# Altium schematic sheet pultboard_buttons.SchDoc(pultboard_buttons)
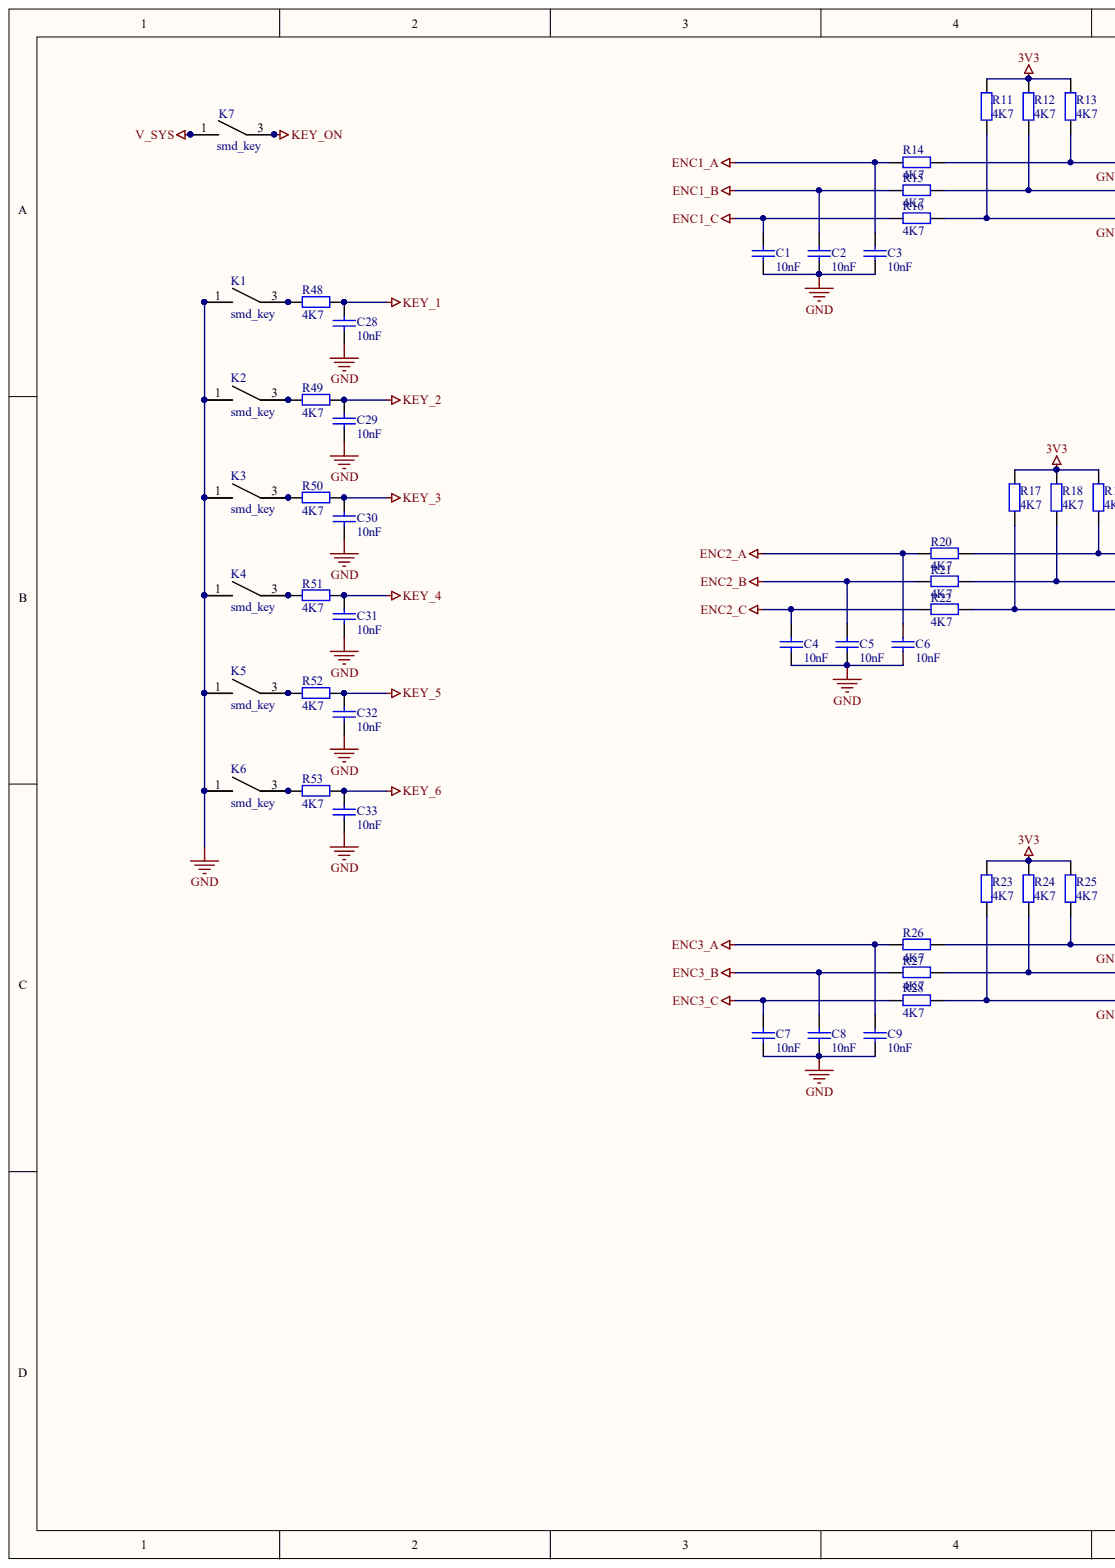
[diagram p0: pultboard_buttons.SchDoc, left]
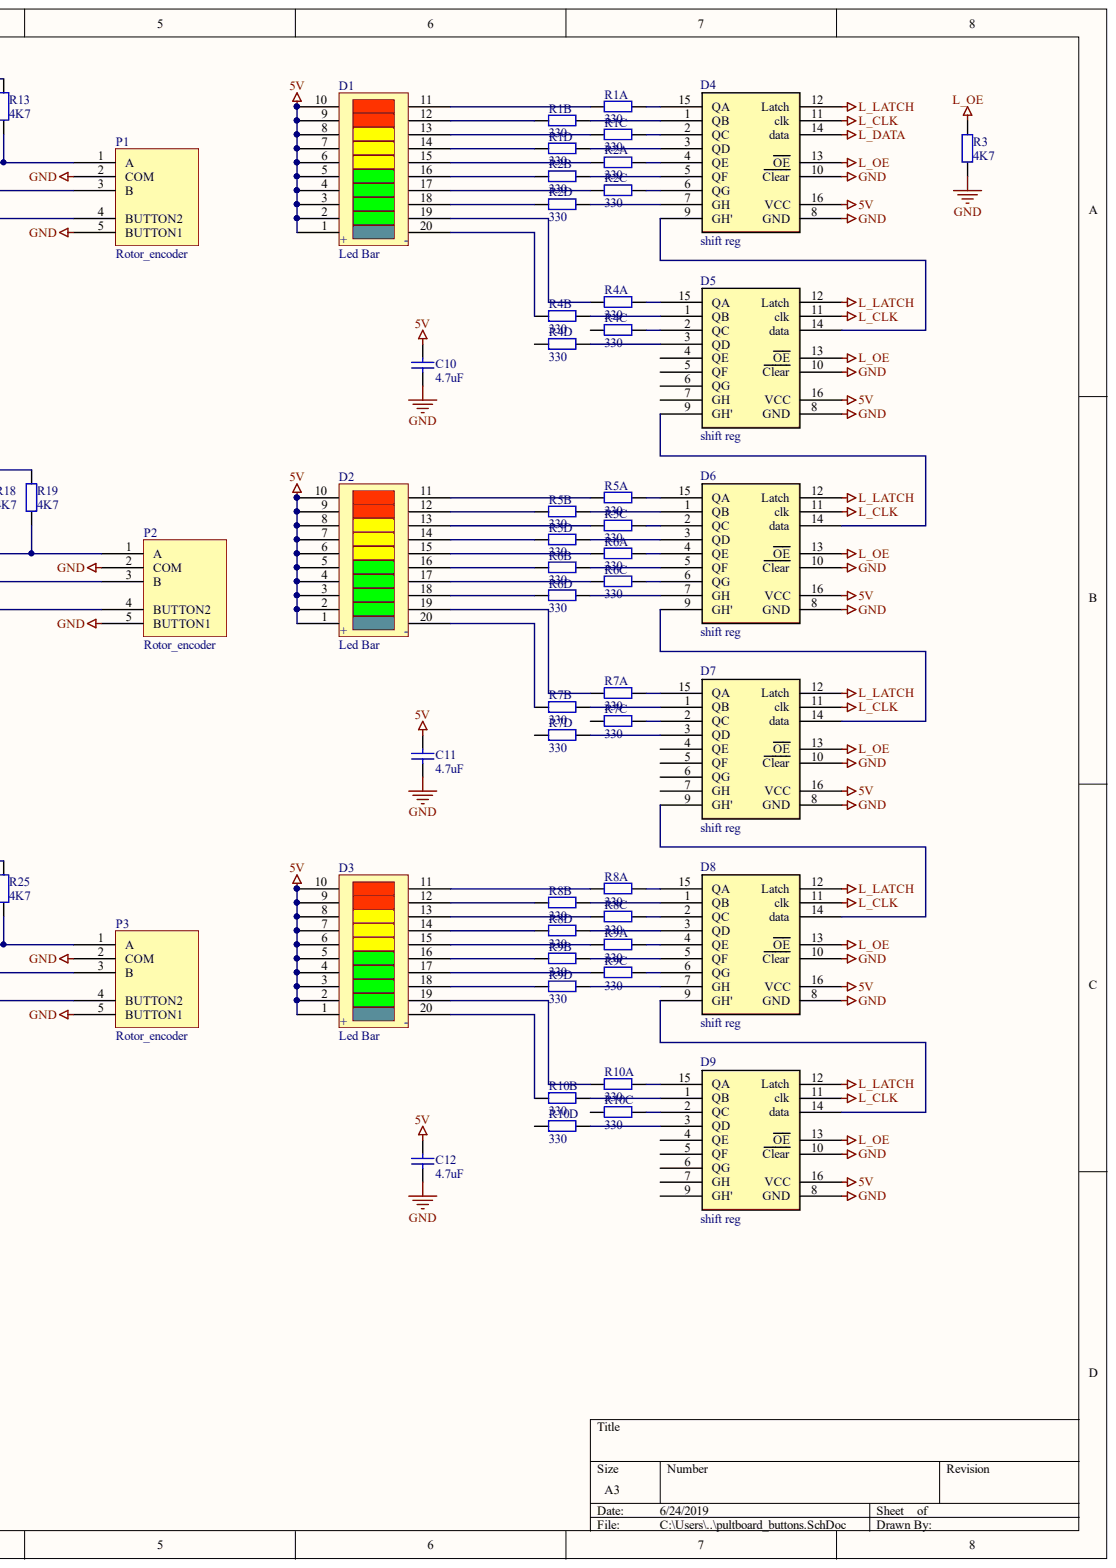
[diagram p1: pultboard_buttons.SchDoc, right]

## Parts
C1  value 10nF  [0603]  (hidden comment: Cap)
C2  value 10nF  [0603]  (hidden comment: Cap)
C3  value 10nF  [0603]  (hidden comment: Cap)
C4  value 10nF  [0603]  (hidden comment: Cap)
C5  value 10nF  [0603]  (hidden comment: Cap)
C6  value 10nF  [0603]  (hidden comment: Cap)
C7  value 10nF  [0603]  (hidden comment: Cap)
C8  value 10nF  [0603]  (hidden comment: Cap)
C9  value 10nF  [0603]  (hidden comment: Cap)
C10  value 4.7uF  [0603]  (hidden comment: Cap)
C11  value 4.7uF  [0603]  (hidden comment: Cap)
C12  value 4.7uF  [0603]  (hidden comment: Cap)
C28  value 10nF  [0603]  (hidden comment: Cap)
C29  value 10nF  [0603]  (hidden comment: Cap)
C30  value 10nF  [0603]  (hidden comment: Cap)
C31  value 10nF  [0603]  (hidden comment: Cap)
C32  value 10nF  [0603]  (hidden comment: Cap)
C33  value 10nF  [0603]  (hidden comment: Cap)
D1  Led Bar  Database Table Name: Led  [b10bbgyr]
D2  Led Bar  Database Table Name: Led  [b10bbgyr]
D3  Led Bar  Database Table Name: Led  [b10bbgyr]
D4  shift reg  [SOIC127P600X175-16N]
D5  shift reg  [SOIC127P600X175-16N]
D6  shift reg  [SOIC127P600X175-16N]
D7  shift reg  [SOIC127P600X175-16N]
D8  shift reg  [SOIC127P600X175-16N]
D9  shift reg  [SOIC127P600X175-16N]
K1  smd_key  [KSC701GLFS]
K2  smd_key  [KSC701GLFS]
K3  smd_key  [KSC701GLFS]
K4  smd_key  [KSC701GLFS]
K5  smd_key  [KSC701GLFS]
K6  smd_key  [KSC701GLFS]
K7  smd_key  [KSC701GLFS]
P1  Rotor_encoder  [EVE-QDBRL416B]
P2  Rotor_encoder  [EVE-QDBRL416B]
P3  Rotor_encoder  [EVE-QDBRL416B]
R1  value 330  [YC124]  (hidden comment: Resx4)  (parts A,B,C,D)
R2  value 330  [YC124]  (hidden comment: Resx4)  (parts A,B,C,D)
R3  value 4K7  [0603]  (hidden comment: Res)
R4  value 330  [YC124]  (hidden comment: Resx4)  (parts A,B,C,D)
R5  value 330  [YC124]  (hidden comment: Resx4)  (parts A,B,C,D)
R6  value 330  [YC124]  (hidden comment: Resx4)  (parts A,B,C,D)
R7  value 330  [YC124]  (hidden comment: Resx4)  (parts A,B,C,D)
R8  value 330  [YC124]  (hidden comment: Resx4)  (parts A,B,C,D)
R9  value 330  [YC124]  (hidden comment: Resx4)  (parts A,B,C,D)
R10  value 330  [YC124]  (hidden comment: Resx4)  (parts A,B,C,D)
R11  value 4K7  [0603]  (hidden comment: Res)
R12  value 4K7  [0603]  (hidden comment: Res)
R13  value 4K7  [0603]  (hidden comment: Res)
R14  value 4K7  [0603]  (hidden comment: Res)
R15  value 4K7  [0603]  (hidden comment: Res)
R16  value 4K7  [0603]  (hidden comment: Res)
R17  value 4K7  [0603]  (hidden comment: Res)
R18  value 4K7  [0603]  (hidden comment: Res)
R19  value 4K7  [0603]  (hidden comment: Res)
R20  value 4K7  [0603]  (hidden comment: Res)
R21  value 4K7  [0603]  (hidden comment: Res)
R22  value 4K7  [0603]  (hidden comment: Res)
R23  value 4K7  [0603]  (hidden comment: Res)
R24  value 4K7  [0603]  (hidden comment: Res)
R25  value 4K7  [0603]  (hidden comment: Res)
R26  value 4K7  [0603]  (hidden comment: Res)
R27  value 4K7  [0603]  (hidden comment: Res)
R28  value 4K7  [0603]  (hidden comment: Res)
R48  value 4K7  [0603]  (hidden comment: Res)
R49  value 4K7  [0603]  (hidden comment: Res)
R50  value 4K7  [0603]  (hidden comment: Res)
R51  value 4K7  [0603]  (hidden comment: Res)
R52  value 4K7  [0603]  (hidden comment: Res)
R53  value 4K7  [0603]  (hidden comment: Res)

## Nets
3V3: R11.2 R12.2 R13.2 R17.2 R18.2 R19.2 R23.2 R24.2 R25.2
5V: C10.2 C11.2 C12.2 D1.1 D1.2 D1.3 D1.4 D1.5 D1.6 D1.7 D1.8 D1.9 D1.10 D2.1 D2.2 D2.3 D2.4 D2.5 D2.6 D2.7 D2.8 D2.9 D2.10 D3.1 D3.2 D3.3 D3.4 D3.5 D3.6 D3.7 D3.8 D3.9 D3.10 D4.16(VCC) D5.16(VCC) D6.16(VCC) D7.16(VCC) D8.16(VCC) D9.16(VCC)
ENC1_A: C3.2 R14.2
ENC1_B: C2.2 R15.2
ENC1_C: C1.2 R16.2
ENC2_A: C6.2 R20.2
ENC2_B: C5.2 R21.2
ENC2_C: C4.2 R22.2
ENC3_A: C9.2 R26.2
ENC3_B: C8.2 R27.2
ENC3_C: C7.2 R28.2
GND: C1.1 C2.1 C3.1 C4.1 C5.1 C6.1 C7.1 C8.1 C9.1 C10.1 C11.1 C12.1 C28.1 C29.1 C30.1 C31.1 C32.1 C33.1 D4.8(GND) D4.10(Clear) D5.8(GND) D5.10(Clear) D6.8(GND) D6.10(Clear) D7.8(GND) D7.10(Clear) D8.8(GND) D8.10(Clear) D9.8(GND) D9.10(Clear) K1.1 K1.2 K2.1 K2.2 K3.1 K3.2 K4.1 K4.2 K5.1 K5.2 K6.1 K6.2 P1.2(COM) P1.5(BUTTON1) P2.2(COM) P2.5(BUTTON1) P3.2(COM) P3.5(BUTTON1) R3.1
KEY_1: C28.2 R48.1
KEY_2: C29.2 R49.1
KEY_3: C30.2 R50.1
KEY_4: C31.2 R51.1
KEY_5: C32.2 R52.1
KEY_6: C33.2 R53.1
KEY_ON: K7.3 K7.4
L_CLK: D4.11(clk) D5.11(clk) D6.11(clk) D7.11(clk) D8.11(clk) D9.11(clk)
L_DATA: D4.14(data)
L_LATCH: D4.12(Latch) D5.12(Latch) D6.12(Latch) D7.12(Latch) D8.12(Latch) D9.12(Latch)
L_OE: D4.13(OE) D5.13(OE) D6.13(OE) D7.13(OE) D8.13(OE) D9.13(OE) R3.2
V_SYS: K7.1 K7.2
NetD1_11: D1.11 R1.1
NetD1_12: D1.12 R1.3
NetD1_13: D1.13 R1.5
NetD1_14: D1.14 R1.7
NetD1_15: D1.15 R2.1
NetD1_16: D1.16 R2.3
NetD1_17: D1.17 R2.5
NetD1_18: D1.18 R2.7
NetD1_19: D1.19 R4.1
NetD1_20: D1.20 R4.3
NetD2_11: D2.11 R5.1
NetD2_12: D2.12 R5.3
NetD2_13: D2.13 R5.5
NetD2_14: D2.14 R5.7
NetD2_15: D2.15 R6.1
NetD2_16: D2.16 R6.3
NetD2_17: D2.17 R6.5
NetD2_18: D2.18 R6.7
NetD2_19: D2.19 R7.1
NetD2_20: D2.20 R7.3
NetD3_11: D3.11 R8.1
NetD3_12: D3.12 R8.3
NetD3_13: D3.13 R8.5
NetD3_14: D3.14 R8.7
NetD3_15: D3.15 R9.1
NetD3_16: D3.16 R9.3
NetD3_17: D3.17 R9.5
NetD3_18: D3.18 R9.7
NetD3_19: D3.19 R10.1
NetD3_20: D3.20 R10.3
NetD4_1: D4.1(QB) R1.4
NetD4_2: D4.2(QC) R1.6
NetD4_3: D4.3(QD) R1.8
NetD4_4: D4.4(QE) R2.2
NetD4_5: D4.5(QF) R2.4
NetD4_6: D4.6(QG) R2.6
NetD4_7: D4.7(GH) R2.8
NetD4_9: D4.9(GH') D5.14(data)
NetD4_15: D4.15(QA) R1.2
NetD5_1: D5.1(QB) R4.4
NetD5_2: D5.2(QC) R4.6
NetD5_3: D5.3(QD) R4.8
NetD5_9: D5.9(GH') D6.14(data)
NetD5_15: D5.15(QA) R4.2
NetD6_1: D6.1(QB) R5.4
NetD6_2: D6.2(QC) R5.6
NetD6_3: D6.3(QD) R5.8
NetD6_4: D6.4(QE) R6.2
NetD6_5: D6.5(QF) R6.4
NetD6_6: D6.6(QG) R6.6
NetD6_7: D6.7(GH) R6.8
NetD6_9: D6.9(GH') D7.14(data)
NetD6_15: D6.15(QA) R5.2
NetD7_1: D7.1(QB) R7.4
NetD7_2: D7.2(QC) R7.6
NetD7_3: D7.3(QD) R7.8
NetD7_9: D7.9(GH') D8.14(data)
NetD7_15: D7.15(QA) R7.2
NetD8_1: D8.1(QB) R8.4
NetD8_2: D8.2(QC) R8.6
NetD8_3: D8.3(QD) R8.8
NetD8_4: D8.4(QE) R9.2
NetD8_5: D8.5(QF) R9.4
NetD8_6: D8.6(QG) R9.6
NetD8_7: D8.7(GH) R9.8
NetD8_9: D8.9(GH') D9.14(data)
NetD8_15: D8.15(QA) R8.2
NetD9_1: D9.1(QB) R10.4
NetD9_2: D9.2(QC) R10.6
NetD9_3: D9.3(QD) R10.8
NetD9_15: D9.15(QA) R10.2
NetK1_3: K1.3 K1.4 R48.2
NetK2_3: K2.3 K2.4 R49.2
NetK3_3: K3.3 K3.4 R50.2
NetK4_3: K4.3 K4.4 R51.2
NetK5_3: K5.3 K5.4 R52.2
NetK6_3: K6.3 K6.4 R53.2
NetP1_1: P1.1(A) R13.1 R14.1
NetP1_3: P1.3(B) R12.1 R15.1
NetP1_4: P1.4(BUTTON2) R11.1 R16.1
NetP2_1: P2.1(A) R19.1 R20.1
NetP2_3: P2.3(B) R18.1 R21.1
NetP2_4: P2.4(BUTTON2) R17.1 R22.1
NetP3_1: P3.1(A) R25.1 R26.1
NetP3_3: P3.3(B) R24.1 R27.1
NetP3_4: P3.4(BUTTON2) R23.1 R28.1

Not connected: D5 4(QE) 5(QF) 6(QG) 7(GH); D7 4(QE) 5(QF) 6(QG) 7(GH); D9 4(QE) 5(QF) 6(QG) 7(GH) 9(GH'); R4 5 7; R7 5 7; R10 5 7

## Library data of the parts
C1-C9 C28-C33 = CC0603JRX7R9BB103@YAGEO: Capacitor; Database Table Name: Capacitor ceramic; Tolerance: 5%; Voltage rated: 50V; Component Kind: Standard; Library Name: passive.DbLib; Link: http://www.elitan.ru/price/item629684; Package: 0603; Part Number: CC0603JRX7R9BB103@YAGEO; Supplier: elitan.ru
C10-C12 = GRM188R60J475KE19D@MURATA: Capacitor; Database Table Name: Capacitor ceramic; Tolerance: 10%; Voltage rated: 6V; Component Kind: Standard; Library Name: passive.DbLib; Link: http://www.elitan.ru/price/item605637; Package: 0603; Part Number: GRM188R60J475KE19D@MURATA; Supplier: elitan.ru
D1-D3 = B10BBGYR: Component Kind: Standard; Library Name: discrete.DbLib; Part Number: B10BBGYR
D4-D9 = 74HC595: Database Table Name: General logic 74HC***; Component Kind: Standard; Default Comment: 74HC595; Library Name: generic ic.DbLib; Package: SOIC 16; Part Number: 74HC595
K1-K7 = KSC701GLFS: Database Table Name: smd key; Number of Positions: 4; Component Kind: Standard; Description: smd_key; Library Name: connectors.DbLib; Mount type: smd; Part Number: KSC701GLFS; Supplier: http://www.chipdip.ru/product/swt-34/
P1-P3 = EVE-QDBRL416B: Rotor_encoder with press button; Database Table Name: Buttons & Encoders; Component Kind: Standard; Library Name: passive.DbLib; Part Number: EVE-QDBRL416B
R1 R2 R4-R10 = YC124-FR-0710KL: Resistor; Database Table Name: Resistor YC124; Tolerance: 1%; Component Kind: Standard; Library Name: passive.DbLib; Package: 0804; Part Number: YC124-FR-0710KL
R3 R11-R28 R48-R53 = R_00042: Resistor; Tolerance: 5%; Component Kind: Standard; Database Table Name: Resistor 0603; Library Name: passive.DbLib; Package: 0603; Part Number: R_00042; Supplier: elitan.ru
